# Altium schematic sheet Battery Pack_P1_REV_1.SchDoc
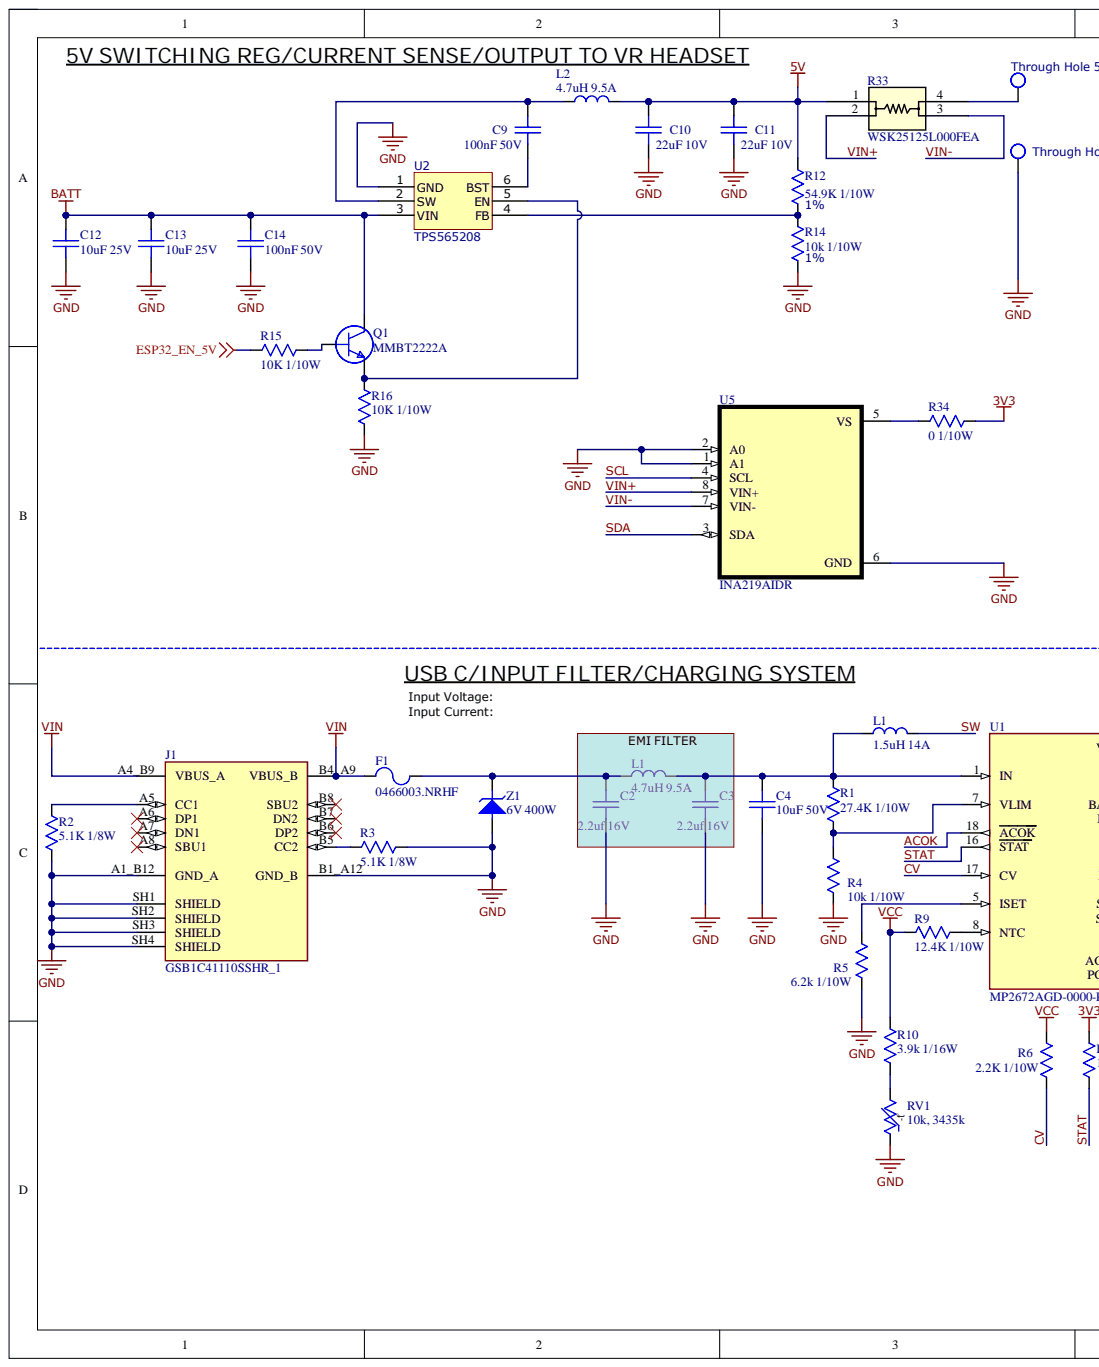
[diagram p0: Battery Pack_P1_REV_1.SchDoc, left]
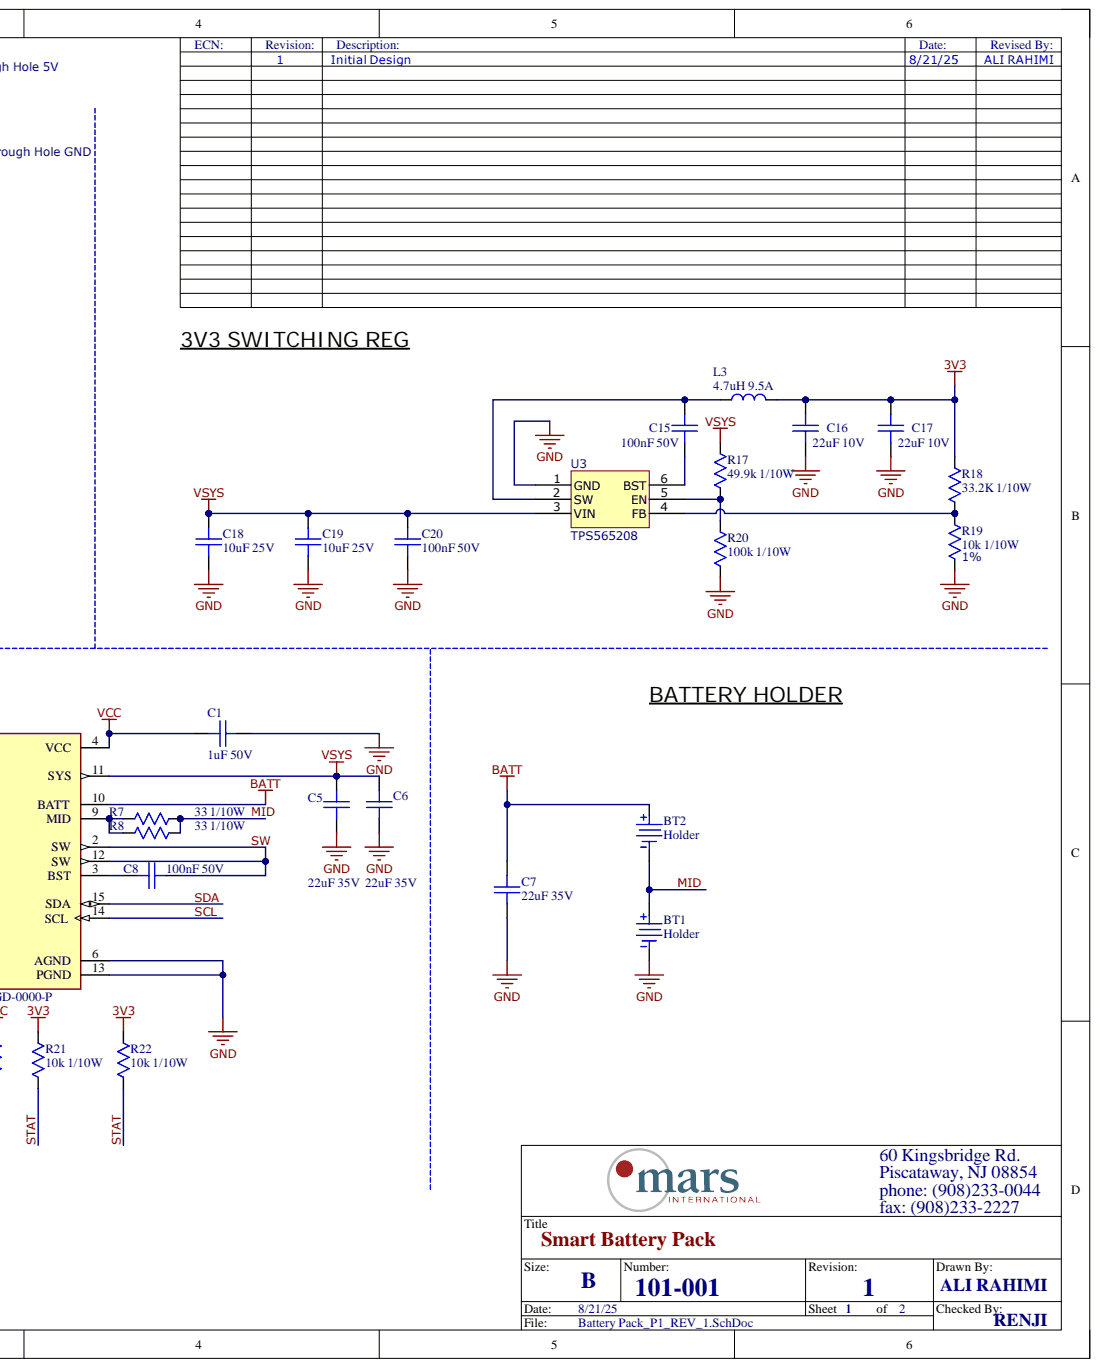
[diagram p1: Battery Pack_P1_REV_1.SchDoc, right]

## Parts
BT1  Holder  [BATTERY_HOLDER_BU2032]
BT2  Holder  [BATTERY_HOLDER_BU2032]
C1  1uF 50V  Voltage Rating: 50V  [IPC-0603]
C2  2.2uf 16V  Voltage Rating: 16V  [IPC-0603]
C3  2.2uf 16V  Voltage Rating: 16V  [IPC-0603]
C4  10uF 50V  [IPC-0805]
C5  22uF 35V  [IPC-0805]
C6  22uF 35V  [IPC-0805]
C7  22uF 35V  Voltage Rating: 35V  [IPC-0805]
C8  100nF 50V  Voltage Rating: 50V  [IPC-0603]
C9  100nF 50V  Voltage Rating: 50V  [IPC-0603]
C10  22uF 10V  Voltage Rating: 10V  [IPC-1206]
C11  22uF 10V  Voltage Rating: 10V  [IPC-1206]
C12  10uF 25V  [IPC-1206]
C13  10uF 25V  Voltage Rating: 25V  [IPC-1206]
C14  100nF 50V  [IPC-0603]
C15  100nF 50V  Voltage Rating: 50V  [IPC-0603]
C16  22uF 10V  Voltage Rating: 10V  [IPC-1206]
C17  22uF 10V  Voltage Rating: 10V  [IPC-1206]
C18  10uF 25V  Voltage Rating: 25V  [IPC-1206]
C19  10uF 25V  Voltage Rating: 25V  [IPC-1206]
C20  100nF 50V  [IPC-0603]
F1  0466003.NRHF  [FUSC3115X66N]
J1  GSB1C41110SSHR_1  [AMPHENOL_GSB1C41110SSHR]
L1  1.5uH 14A  [IND_SRP5030CA_BRN]
L1  4.7uH 9.5A  RMS Current (Irms): 9.5A  [IND_11X10MM]
L2  4.7uH 9.5A  RMS Current (Irms): 9.5A  [IND_11X10MM]
L3  4.7uH 9.5A  RMS Current (Irms): 9.5A  [IND_11X10MM]
Q1  MMBT2222A  [SOT-23_BEC]
R1  27.4K 1/10W  Voltage Rating: 50V  [IPC-0603]
R2  5.1K 1/8W  [IPC-0805]
R3  5.1K 1/8W  [IPC-0805]
R4  10k 1/10W  [IPC-0603]
R5  6.2k 1/10W  [IPC-0603]
R6  2.2K 1/10W  [IPC-0603]
R7  33 1/10W  [IPC-0603]
R8  33 1/10W  [IPC-0603]
R9  12.4K 1/10W  [IPC-0603]
R10  3.9k 1/16W  [IPC-0402]
R12  54.9K 1/10W  Tolerance: 1%  [IPC-0603]
R14  10k 1/10W  Tolerance: 1%  [IPC-0603]
R15  10K 1/10W  [IPC-0603]
R16  10K 1/10W  [IPC-0603]
R17  49.9k 1/10W  [IPC-0603]
R18  33.2K 1/10W  [IPC-0603]
R19  10k 1/10W  Tolerance: 1%  [IPC-0603]
R20  100k 1/10W  [IPC-0603]
R21  10k 1/10W  [IPC-0603]
R22  10k 1/10W  [IPC-0603]
R33  WSK25125L000FEA  [WSK2512_0.005-0.2_VIS]
R34  0 1/10W  [IPC-0603]
RV1  10k, 3435k  [NA]
Through_Hole_5V  TP  [TP_TH_40]  (value not drawn)
Through_Hole_GND  TP  [TP_TH_40]  (value not drawn)
U1  MP2672AGD-0000-P  [IC_MP2672AGD-0000-P]
U2  TPS565208  [SOT23-6]
U3  TPS565208  [SOT23-6]
U5  INA219AIDR  [SOIC127P599X175-8N]
Z1  6V 400W  [SMA_DIODE]

## Nets
3V3: C16.2 C17.2 L3.2 R18.2 R21.2 R22.2 R34.2
5V: C10.2 C11.2 L2.2 R12.2 R33.1
BATT: BT2.2 C7.2 C12.2 C13.2 C14.2 Q1.C U1.10(BATT) U2.3(VIN)
ESP32_EN_5V: R15.2
GND: BT1.1 C1.2 C2.1 C3.1 C4.1 C5.1 C6.1 C7.1 C10.1 C11.1 C12.1 C13.1 C14.1 C16.1 C17.1 C18.1 C19.1 C20.1 J1.A1_B12(GND_A) J1.B1_A12(GND_B) J1.SH1(SHIELD) J1.SH2(SHIELD) J1.SH3(SHIELD) J1.SH4(SHIELD) R2.1 R3.1 R4.1 R5.1 R14.1 R16.1 R19.1 R20.2 RV1.1 Through_Hole_GND.1 U1.6(AGND) U1.13(PGND) U2.1(GND) U3.1(GND) U5.1(A1) U5.2(A0) U5.6(GND) Z1.A
VCC: C1.1 R6.2 R9.1 R10.2 U1.4(VCC)
VIN: F1.1 J1.A4_B9(VBUS_A) J1.B4_A9(VBUS_B)
VSYS: C5.2 C6.2 C18.2 C19.2 C20.2 R17.2 U1.11(SYS) U3.3(VIN)
ACOK: U1.18(ACOK)
CV: R6.1 U1.17(CV)
MID: BT1.2 BT2.1 R7.2 R8.2
SCL: U1.14(SCL) U5.4(SCL)
SDA: U1.15(SDA) U5.3(SDA)
STAT: R21.1 R22.1 U1.16(STAT)
SW: C8.2 L1.2 U1.2(SW) U1.12(SW)
VIN+: R33.2 U5.8(VIN+)
VIN-: R33.3 U5.7(VIN-)
NetC2_2: C2.2 F1.2 L1.1 Z1.K
NetC3_2: C3.2 C4.2 L1.1 L1.2 R1.2 U1.1(IN)
NetC8_1: C8.1 U1.3(BST)
NetC9_1: C9.1 U2.6(BST)
NetC9_2: C9.2 L2.1 U2.2(SW)
NetC15_1: C15.1 U3.6(BST)
NetC15_2: C15.2 L3.1 U3.2(SW)
NetJ1_A5: J1.A5(CC1) R2.2
NetJ1_B5: J1.B5(CC2) R3.2
NetQ1_B: Q1.B R15.1
NetQ1_E: Q1.E R16.2 U2.5(EN)
NetR1_1: R1.1 R4.2 U1.7(VLIM)
NetR5_2: R5.2 U1.5(ISET)
NetR7_1: R7.1 R8.1 U1.9(MID)
NetR9_2: R9.2 U1.8(NTC)
NetR10_1: R10.1 RV1.2
NetR12_1: R12.1 R14.2 U2.4(FB)
NetR17_1: R17.1 R20.1 U3.5(EN)
NetR18_1: R18.1 R19.2 U3.4(FB)
NetR33_4: R33.4 Through_Hole_5V.1
NetR34_1: R34.1 U5.5(VS)

Not connected: J1 A6(DP1) A7(DN1) A8(SBU1) B6(DP2) B7(DN2) B8(SBU2)

## Library data of the parts
BT1 BT2 = O-0652-01: BATTERY HOLDER 2032 SMD; AVL Part Numbers: BU2032SM-BT-GTR; Manufacturer Part Number: BU2032SM-JJ-GTR; Approved: Yes; AVL Vendors: MPD; Connector Type: Snap-In; Current: NA; Database Table Name: Battery Terminal; Plating: 0.020" thick PHOS-BRONZE, GOLD FLASH; Case/Package: SMT; Component Kind: Standard; Height: 0.21"; Length: 1.12"; Manufacturer: MPD; Material: 30% glass reinforced Liquid           Crystal Polymer resin; Max Operating Temperature: 280°C; Min Operating Temperature: -40°C; PT Number: O-0652-01; Supplier 1: Digikey; Supplier Part Number 1: BU2032SM-ND; Voltage: NA; Width: 0.79"; Approved By: ADMIN; Created By: ADMIN; Library Name: MarsLibrary_Test_Environment.DbLib
C1 = SC-0786-01: CAP CER 1UF 50V X5R 0603; Manufacturer: Taiyo Yuden; Manufacturer Part Number: UMK107BJ105KA-T; Approved: Yes; Database Table Name: Capacitors - Surface Mount; Dielectric Material: Ceramic; ESR: NA; Tolerance: 10%; Case/Package: 0603; Component Kind: Standard; Dielectric: X5R; Height: 0.035"; Length: 0.063"; Life(Hours): NA; Max Operating Temperature: 85°C; Min Operating Temperature: -55°C; PT Number: SC-0786-01; Ripple Current: NA; Supplier 1: Digikey; Supplier Part Number 1: 587-2400-2-ND; Width: 0.031"; Approved By: ADMIN; Created By: ADMIN; Library Name: MarsLibrary_Test_Environment.DbLib
C2 C3 = SC-0561-01: CAP CER 2.2UF 16V X5R 0603; Manufacturer: Kemet; Manufacturer Part Number: C0603C225K4PACTU; Approved: Yes; Database Table Name: Capacitors - Surface Mount; Dielectric Material: Ceramic; ESR: NA; Tolerance: 10%; Case/Package: 0603; Component Kind: Standard; Dielectric: X5R; Height: 0.034"; Length: 0.063"; Life(Hours): NA; Max Operating Temperature: 85°C; Min Operating Temperature: -55°C; PT Number: SC-0561-01; Ripple Current: NA; Supplier 1: Digikey; Supplier Part Number 1: 399-7886-1-ND; Width: 0.031"; Approved By: ADMIN; Created By: ADMIN; Library Name: MarsLibrary_Test_Environment.DbLib
C4 = SC-0956-01: CAP CER 10UF 50V X5R 0805; Manufacturer: Murata; Manufacturer Part Number: GRM21BR61H106ME43L; Approved: Yes; Database Table Name: Capacitors - Surface Mount; Dielectric Material: Ceramic; ESR: NA; Tolerance: 20%; Case/Package: 0805; Component Kind: Standard; Dielectric: X5R; Height: 0.057"; Length: 0.079"; Life(Hours): NA; Max Operating Temperature: 85°C; Min Operating Temperature: -55°C; PT Number: SC-0956-01; Ripple Current: NA; Supplier 1: Digikey; Supplier Part Number 1: 490-18664-2-ND; Voltage Rating: 50V; Width: 0.049"; Approved By: BKW; Created By: AW; Library Name: MarsLibrary_Test_Environment.DbLib
C5-C7 = SC-0905-01: CAP CER 22UF 35V X5R 0805; Manufacturer: TDK; Manufacturer Part Number: C2012X5R1V226M125AC; Approved: No; Database Table Name: Capacitors - Surface Mount; Dielectric Material: Ceramic; ESR: NA; Tolerance: 20%; Case/Package: 0805; Component Kind: Standard; Dielectric: X5R; Height: 0.057"; Length: 0.079"; Life(Hours): NA; Max Operating Temperature: 85°C; Min Operating Temperature: -55°C; PT Number: SC-0905-01; Ripple Current: NA; Supplier 1: Digikey; Supplier Part Number 1: 445-14428-2-ND; Width: 0.049"; Created By: BKW; Library Name: MarsLibrary_Test_Environment.DbLib
  C5 C6: Voltage Rating: 35V
C8 = SC-0201-01: CAP CER 0.1uF 50V X7R 0603; Manufacturer: AVX; Manufacturer Part Number: 06035C104KAT2A; Approved: Yes; Database Table Name: Capacitors - Surface Mount; Dielectric Material: Ceramic; ESR: NA; Tolerance: 10%; Case/Package: 0603; Component Kind: Standard; Dielectric: X7R; Height: 0.035"; Length: 0.063"; Life(Hours): NA; Max Operating Temperature: 125°C; Min Operating Temperature: -55°C; PT Number: SC-0201-01; Ripple Current: NA; Supplier 1: Mouser; Supplier Part Number 1: 581-06035C104KAT2A; Width: 0.032"; Approved By: ADMIN; Created By: ADMIN; Library Name: MarsLibrary_Test_Environment.DbLib
C9 C14 C15 C20 = SC-0201-01: CAP CER 0.1uF 50V X7R 0603; AVL Part Numbers: GRM188R71H104KA93D, CL10B104KB8NNNC, 0603B104K500BD, CC0603KRX7R9BB104, C0603T104K5RALTU, C1608X7R104KGTS; Manufacturer: AVX; Manufacturer Part Number: 06035C104KAT2A; Approved: False; AVL Vendors: Murata, Samsung, Team Young, Yageo, Kemet , Darfon; Database Table Name: Capacitors - Surface Mount; Dielectric Material: Ceramic; ESR: NA; Tolerance: 10%; Case/Package: 0603; Component Kind: Standard; Dielectric: X7R; Height: 0.035"; Length: 0.063"; Life(Hours): NA; Max Operating Temperature: 125°C; Min Operating Temperature: -55°C; PT Number: SC-0201-01; Ripple Current: NA; Supplier 1: Mouser; Supplier Part Number 1: 581-06035C104KAT2A; Width: 0.032"; Library Name: MarsLibrary_Test_Environment.DbLib
  C14 C20: Voltage Rating: 50V
C10 C11 C16 C17 = SC-0627-01: Cap Ceramic 22uF 10V X7R 10% SMD 1206 125C Embossed T/R; AVL Part Numbers: CL31B226KPHNNNE; Approved: True; AVL Vendors: Samsung; Database Table Name: Capacitors - Surface Mount; ESR: NA; Case/Package: 1206; Component Kind: Standard; Dielectric: X7R; Dielectric Material: Ceramic; Height: 0.071"; Length: 0.126"; Life(Hours): NA; Manufacturer: Samsung; Manufacturer Part Number: CL31B226KPHNNNE; Max Operating Temperature: 125°C; Min Operating Temperature: -55°C; PT Number: SC-0627-01; Ripple Current: NA; Supplier 1: Digi-Key; Supplier Part Number 1: 1276-2769-1-ND; Tolerance: 10%; Width: 0.063"; Library Name: MarsLibrary_Test_Environment.DbLib
C12 C13 C18 C19 = SC-0754-01: Multilayer Ceramic Capacitors MLCC - SMD/SMT 10uF 25Volts X7R 20%; AVL Part Numbers: GRM31CR71E106MA12L; Approved: True; AVL Vendors: Murata; Database Table Name: Capacitors - Surface Mount; ESR: NA; Case/Package: 1206; Component Kind: Standard; Dielectric: X7R; Dielectric Material: Ceramic; Height: 0.071"; Length: 0.126"; Life(Hours): NA; Manufacturer: Murata; Manufacturer Part Number: GRM31CR71E106MA12L; Max Operating Temperature: 125°C; Min Operating Temperature: -55°C; PT Number: SC-0754-01; Ripple Current: NA; Supplier 1: Digi-Key; Supplier Part Number 1: 490-6519-1-ND; Tolerance: 20%; Width: 0.063"; Library Name: MarsLibrary_Test_Environment.DbLib
  C12: Voltage Rating: 25V
F1 = 0466003.NRHF: 478 Series 1206 Fast-Acting Fuse; MF: Littelfuse Inc.; Check_prices: https://www.snapeda.com/parts/0466003.NRHF/Littelfuse+Inc./view-part/?ref=eda; Component Kind: Standard; Library Name: Schlib1.SchLib; MP: 0466003.NRHF; Package: 1206 Littelfuse Inc.; SnapEDA_Link: https://www.snapeda.com/parts/0466003.NRHF/Littelfuse+Inc./view-part/?ref=snap
J1 = GSB1C41110SSHR_1: USB2.0, Type C, Top mount, Center Height 1.63mm, Single Row Surface Mount; MF: Amphenol Canada; Check_prices: https://www.snapeda.com/parts/GSB1C41110SSHR/Amphenol/view-part/?ref=eda; Component Kind: Standard; Library Name: Schlib1.SchLib; MP: GSB1C41110SSHR; Package: None; SnapEDA_Link: https://www.snapeda.com/parts/GSB1C41110SSHR/Amphenol/view-part/?ref=snap
L1 = L-0210-01: IND SMD 2.2UH 7A; Manufacturer: Bourns; Manufacturer Part Number: SRP5030CC-2R2M; Approved: Yes; Database Table Name: Inductors; DC Resistance (DCR): 32mOhm; Material: Ferrite; Saturation Current: NA; Shielding: Shielded; Tolerance: 20%; Case/Package: 5.7x5.2mm; Component Kind: Standard; Height: 3mm; Length: 5.7mm; Max Operating Temperature: 125°C; Min Operating Temperature: -40°C; PT Number: L-0210-01; RMS Current (Irms): 7A; RoHS: Yes; Supplier 1: Digikey; Supplier Part Number 1: 118-SRP5030CC-2R2MCT-ND; Width: 5.2mm; Approved By: BKW; Created By: MAR; Library Name: MarsLibrary_Test_Environment.DbLib
L1 = L-0191-01: FIXED IND 4.7UH 9.5A 16.5MOHM SMD SHIELDED; Manufacturer: Laird; Manufacturer Part Number: MGV10044R7M-10; Approved: Yes; Database Table Name: Inductors; Material: Ferrite; Saturation Current: 17A; Tolerance: 20%; Case/Package: 11x10mm; Component Kind: Standard; DC Resistance (DCR): 16.5 mOhms; Height: 0.17"; Length: 0.452"; Max Operating Temperature: 125°C; Min Operating Temperature: -40°C; PT Number: L-0191-01; RoHS: Yes; Shielding: Shielded; Supplier 1: Digikey; Supplier Part Number 1: 240-2819-1-ND; Width: 0.405"; Approved By: ADMIN; Created By: ADMIN; Library Name: MarsLibrary_Test_Environment.DbLib
L2 L3 = L-0191-01: FIXED IND 4.7UH 9.5A 16.5MOHM SMD SHIELDED; AVL Part Numbers: MGV10044R7M-10; Approved: False; AVL Vendors: Laird; Database Table Name: Inductors; Material: Ferrite; Saturation Current: 17A; Tolerance: 20%; Case/Package: 11x10mm; Component Kind: Standard; DC Resistance (DCR): 16.5 mOhms; Height: 0.17"; Length: 0.452"; Manufacturer: Laird; Manufacturer Part Number: MGV10044R7M-10; Max Operating Temperature: 125°C; Min Operating Temperature: -40°C; PT Number: L-0191-01; Shielding: Shielded; Supplier 1: Digikey; Supplier Part Number 1: 240-2819-1-ND; Width: 0.405"; Library Name: MarsLibrary_Test_Environment.DbLib
Q1 = T-0027-01: TRANS NPN 40V 0.6A SOT-23; Manufacturer: Diodes; Manufacturer Part Number: MMBT2222A-7-F; Approved: Yes; Collector Base Voltage (VCBO): 75V; Collector Emitter Saturation Voltage: 300mV; Current Rating: 600mA; Database Table Name: Transistors - Bipolar; Emitter Base Voltage (VEBO): 6V; hFE/Beta Min: 100; Power Dissipation: 300mW; Case/Package: SOT-23-3; Collector Emitter Voltage (VCEO): 40V; Component Kind: Standard; Height: 1.1mm; Length: 3.0mm; Max Collector Current: 600mA; Max Operating Temperature: 150°C; Min Operating Temperature: -55°C; PT Number: T-0027-01; RoHS: Yes; Supplier 1: Digikey; Supplier Part Number 1: MMBT2222A-FDICT-ND; Width: 2.5mm; Approved By: ADMIN; Created By: ADMIN; Library Name: MarsLibrary_Test_Environment.DbLib
R1 = R-1015-02: RES SMD 27.4K 1% 1/10W 0603; Manufacturer: Yageo; Manufacturer Part Number: RC0603FR-0727K4L; Approved: Yes; Array: NA; Database Table Name: Resistors; Power Rating: 100mW; Temperature Coefficient: ±100ppm/°C; Case/Package: 0603; Component Kind: Standard; Composition: Thick Film; Height: 0.022"; Length: 0.063"; Max Operating Temperature: 155°C; Min Operating Temperature: -55°C; PT Number: R-1015-02; RoHS: Yes; Supplier 1: Digikey; Supplier Part Number 1: 311-27.4KHRTR-ND; Tolerance: 1%; Width: 0.031"; Approved By: BKW; Created By: ADMIN; Library Name: MarsLibrary_Test_Environment.DbLib
R2 R3 = R-1751-01: RES SMD 5.1K OHM 5% 1/8W 0805; Manufacturer: Panasonic; Manufacturer Part Number: ERJ-6GEYJ512V; Approved: No; Array: NA; Database Table Name: Resistors; Power Rating: 125mW; Temperature Coefficient: ±200ppm/°C; Voltage Rating: 150V; Case/Package: 0805; Component Kind: Standard; Composition: Thick Film; Height: 0.020"; Length: .079"; Max Operating Temperature: 155°C; Min Operating Temperature: -55°C; PT Number: R-1751-01; RoHS: Yes; Supplier 1: Digikey; Supplier Part Number 1: P5.1KATR-ND; Tolerance: 5%; Width: 0.049"; Created By: BKW; Library Name: MarsLibrary_Test_Environment.DbLib
R4 = R-0652-01: RES SMD 10K OHM 1% 1/10W 0603; Manufacturer: Yageo; Manufacturer Part Number: RC0603FR-0710KL; Approved: Yes; Array: NA; Database Table Name: Resistors; Power Rating: 100mW; Temperature Coefficient: ±100ppm/°; Voltage Rating: 75V; Case/Package: 0603; Component Kind: Standard; Composition: Thick Film; Height: 0.022"; Length: 0.063"; Max Operating Temperature: 155°C; Min Operating Temperature: -55°C; PT Number: R-0652-01; RoHS: Yes; Supplier 1: Digikey; Supplier Part Number 1: 311-10.0KHRTR-ND; Tolerance: 1%; Width: 0.031"; Approved By: ADMIN; Created By: ADMIN; Library Name: MarsLibrary_Test_Environment.DbLib
R5 = R-2059-01: RES 6.2K OHM 1% 1/10W 0603; Manufacturer: Yageo; Manufacturer Part Number: RC0603FR-076K2L; Approved: No; Array: NA; Database Table Name: Resistors; Power Rating: 100mW; Temperature Coefficient: ±100ppm/°C; Voltage Rating: 75V; Case/Package: 0603; Component Kind: Standard; Composition: Thick Film; Height: 0.022"; Length: 0.063"; Max Operating Temperature: 155°C; Min Operating Temperature: -55°C; PT Number: R-2059-01; RoHS: Yes; Supplier 1: Digikey; Supplier Part Number 1: 311-6.20KHRTR-ND; Tolerance: 1%; Width: 0.031"; Created By: BKW; Library Name: MarsLibrary_Test_Environment.DbLib
R6 = R-0073-01: RES SMD 2.2K OHM 5% 1/10W 0603; Manufacturer: Yageo; Manufacturer Part Number: RC0603JR-072K2; Approved: Yes; Array: NA; Database Table Name: Resistors; Power Rating: 100mW; Temperature Coefficient: ±100ppm/°C; Voltage Rating: 75V; Case/Package: 0603; Component Kind: Standard; Composition: Thick Film; Height: 0.022"; Length: 0.063"; Max Operating Temperature: 155°C; Min Operating Temperature: -55°C; PT Number: R-0073-01; RoHS: Yes; Supplier 1: Digikey; Supplier Part Number 1: 311-2.2KGRTR-ND; Tolerance: 5%; Width: 0.031"; Approved By: ADMIN; Created By: ADMIN; Library Name: MarsLibrary_Test_Environment.DbLib
R7 R8 = R-0124-01: RES SMD 33 OHM 5% 1/10W 0603; Manufacturer: Panasonic; Manufacturer Part Number: ERJ-3GEYJ330V  ERJ-3GEYJ330V; Approved: Yes; Array: NA; Database Table Name: Resistors; Power Rating: 100mW; Temperature Coefficient: ±200ppm/°C; Voltage Rating: 75V; Case/Package: 0603; Component Kind: Standard; Composition: Thick Film; Height: 0.022"; Length: 0.063"; Max Operating Temperature: 155°C; Min Operating Temperature: -55°C; PT Number: R-0124-01; RoHS: Yes; Supplier 1: Digikey; Supplier Part Number 1: P33GTR-ND; Tolerance: 5%; Width: 0.031"; Approved By: BKW; Created By: ADMIN; Library Name: MarsLibrary_Test_Environment.DbLib
R9 = R-0952-01: RES 12.4K OHM 1% 1/10W 0603; Manufacturer: Vishay; Manufacturer Part Number: CRCW060312K4FKEA; Approved: Yes; Array: NA; Database Table Name: Resistors; Power Rating: 100mW; Temperature Coefficient: ±100ppm/°C; Voltage Rating: 75V; Case/Package: 0603; Component Kind: Standard; Composition: Thick Film; Height: 0.020"; Length: 0.063"; Max Operating Temperature: 155°C; Min Operating Temperature: -55°C; PT Number: R-0952-01; RoHS: Yes; Supplier 1: Digikey; Supplier Part Number 1: 541-12.4KHTR-ND; Tolerance: 1%; Width: 0.031"; Approved By: BKW; Created By: DJS; Library Name: MarsLibrary_Test_Environment.DbLib
R10 = R-1543-01: RES SMD 3.9K OHM 5% 1/16W 0402; Manufacturer: Yageo; Manufacturer Part Number: RC0402JR-073K9L; Approved: Yes; Array: NA; Database Table Name: Resistors; Power Rating: 62.5mW; Temperature Coefficient: ±100ppm/°C; Voltage Rating: 50V; Case/Package: 0402; Component Kind: Standard; Composition: Thick Film; Height: 0.016"; Length: 0.039"; Max Operating Temperature: 155°C; Min Operating Temperature: -55°C; PT Number: R-1543-01; RoHS: Yes; Supplier 1: Digikey; Supplier Part Number 1: 311-3.9KJRTR-ND; Tolerance: 5%; Width: 0.020"; Approved By: ADMIN; Created By: ADMIN; Library Name: MarsLibrary_Test_Environment.DbLib
R12 = R-1822-01: RES SMD 54.9K OHM 1% 1/10W 0603; AVL Part Numbers: RK73H1JTTD5492F; Approved: True; Array: NA; AVL Vendors: Koa; Database Table Name: Resistors; Power Rating: 100mW; Temperature Coefficient: ±100ppm/°C; Voltage Rating: 75V; Case/Package: 0603; Component Kind: Standard; Composition: Thick FIlm; Height: 0.018"; Length: 0.063"; Manufacturer: Koa; Manufacturer Part Number: RK73H1JTTD5492F; Max Operating Temperature: 155°C; Min Operating Temperature: -55°C; PT Number: R-1822-01; RoHS: False; Supplier 1: Digikey; Supplier Part Number 1: 2019-RK73H1JTTD5492FCT-ND; Width: 0.031"; Library Name: MarsLibrary_Test_Environment.DbLib
R14 R19 = R-0652-01: RES SMD 10K OHM 1% 1/10W 0603; AVL Part Numbers: NRC06F1002TRF, RC0603FR-0710KL, MCR03EZPX1002, RCT03103FLF, RCT03FT103, RCT0310KFLF; Manufacturer: Yageo; Manufacturer Part Number: RC0603FR-0710KL; Approved: True; Array: NA; AVL Vendors: NIC, Yageo, Rohm, HK Resisor, HK Resisor, HK Resistor; Database Table Name: Resistors; Power Rating: 100mW; Temperature Coefficient: ±100ppm/°; Voltage Rating: 75V; Case/Package: 0603; Component Kind: Standard; Composition: Thick Film; Height: 0.022"; Length: 0.063"; Max Operating Temperature: 155°C; Min Operating Temperature: -55°C; PT Number: R-0652-01; RoHS: True; Supplier 1: Digikey; Supplier Part Number 1: 311-10.0KHRTR-ND; Width: 0.031"; Library Name: MarsLibrary_Test_Environment.DbLib
R15 R16 = R-0592-01: RES 10K OHM 5% 1/10W 0603; Manufacturer: Yageo; Manufacturer Part Number: RC0603JR-0710KL; Approved: Yes; Array: NA; Database Table Name: Resistors; Power Rating: 100mW; Temperature Coefficient: ±100ppm/°C; Voltage Rating: 75V; Case/Package: 0603; Component Kind: Standard; Composition: Thick Film; Height: 0.022"; Length: 0.063"; Max Operating Temperature: 155°C; Min Operating Temperature: -55°C; PT Number: R-0592-01; RoHS: Yes; Supplier 1: Digikey; Supplier Part Number 1: 311-10KGRCT-ND; Tolerance: 5%; Width: 0.031"; Approved By: BKW; Created By: MAR; Library Name: MarsLibrary_Test_Environment.DbLib
R17 = R-1077-02: RES SMD 49.9K OHM 1% 1/10W 0603; Manufacturer: Yageo; Manufacturer Part Number: RC0603FR-0749K9L; Approved: Yes; Array: NA; Database Table Name: Resistors; Power Rating: 100mW; Temperature Coefficient: ±100ppm/°; Voltage Rating: 50V; Case/Package: 0603; Component Kind: Standard; Composition: Thick Film; Height: 0.022"; Length: 0.063"; Max Operating Temperature: 155°C; Min Operating Temperature: -55°C; PT Number: R-1077-02; RoHS: Yes; Supplier 1: Digikey; Supplier Part Number 1: 311-49.9KHRCT-ND; Tolerance: 1%; Width: 0.031"; Approved By: ADMIN; Created By: ADMIN; Library Name: MarsLibrary_Test_Environment.DbLib
R18 = R-1507-01: RES SMD 33.2K OHM 1% 1/10W 0603; Manufacturer: Yageo; Manufacturer Part Number: RC0603FR-0733K2L; Approved: Yes; Array: NA; Database Table Name: Resistors; Power Rating: 100mW; Temperature Coefficient: ±100ppm/°C; Voltage Rating: 75V; Case/Package: 0603; Component Kind: Standard; Composition: Thick FIlm; Height: 0.022"; Length: 0.063"; Max Operating Temperature: 155°C; Min Operating Temperature: -55°C; PT Number: R-1507-01; RoHS: Yes; Supplier 1: Digikey; Supplier Part Number 1: 311-33.2KHRTR-ND; Tolerance: 1%; Width: 0.031"; Approved By: ADMIN; Created By: ADMIN; Library Name: MarsLibrary_Test_Environment.DbLib
R20 = R-0653-01: RES SMD 100K OHM 1% 1/10W 0603; AVL Part Numbers: NRC06F1003TRF; Manufacturer: Vishay Dale; Manufacturer Part Number: CRCW0603100KFKEA; Approved: True; Array: NA; AVL Vendors: NIC; Database Table Name: Resistors; Power Rating: 100mW; Temperature Coefficient: ±100ppm/°; Voltage Rating: 75V; Case/Package: 0603; Component Kind: Standard; Composition: Thick Film; Height: 0.022"; Length: 0.063"; Max Operating Temperature: 155°C; Min Operating Temperature: -55°C; PT Number: R-0653-01; RoHS: True; Supplier 1: Digikey; Supplier Part Number 1: 541-100KHCT-ND; Tolerance: 1%; Width: 0.031"; Library Name: MarsLibrary_Test_Environment.DbLib
R21 R22 = R-0104-01: RES SMD 10K OHM 5% 1/10W 0603; Manufacturer: Yageo; Manufacturer Part Number: RC0603JR-0710KL; Approved: Yes; Array: NA; Database Table Name: Resistors; Power Rating: 100mW; Temperature Coefficient: ±100ppm/°; Voltage Rating: 75V; Case/Package: 0603; Component Kind: Standard; Composition: Thick Film; Height: 0.031"; Length: 0.063"; Max Operating Temperature: 155°C; Min Operating Temperature: -55°C; PT Number: R-0104-01; RoHS: Yes; Supplier 1: Digikey; Supplier Part Number 1: 311-10KGRCT-ND; Tolerance: 5%; Width: 0.031"; Approved By: ADMIN; Created By: ADMIN; Library Name: MarsLibrary_Test_Environment.DbLib
R33 = WSK25125L000FEA: WSK-2512 .005 1% EA e3; Manufacturer_Name: Vishay; Component Kind: Standard; Datasheet: https://www.vishay.com/doc?30108; Library Name: Schlib1.SchLib; Manufacturer_Part_Number: WSK25125L000FEA; RefDes: RefDes; Type: Type; Copyright: Copyright (C) 2025 Ultra Librarian. All rights reserved.
R34 = R-0103-01: RES SMD 0 OHM 5% 1/10W 0603; Manufacturer: Panasonic; Manufacturer Part Number: ERJ-3GEY0R00V; Approved: Yes; Array: NA; Database Table Name: Resistors; Power Rating: 100mW; Temperature Coefficient: ±100ppm/°C; Voltage Rating: 75V; Case/Package: 0603; Component Kind: Standard; Composition: Thick Film; Height: 0.018"; Length: 0.063"; Max Operating Temperature: 155°C; Min Operating Temperature: -55°C; PT Number: R-0103-01; RoHS: Yes; Supplier 1: Digikey; Supplier Part Number 1: P0.0GCT-ND; Tolerance: 5%; Width: 0.031"; Approved By: ADMIN; Created By: ADMIN; Library Name: MarsLibrary_Test_Environment.DbLib
RV1 = R-2070-01: NTC THERMISTOR 10KOHM 1%; Manufacturer: Semitec USA Corp; Manufacturer Part Number: 103AT-11; Approved: No; Beta Value: 3435; Calibration temperature: 25°C; Database Table Name: RTDs (PTC,NTC,Thermistors); Power: 13mW; Voltage: 1000VAC; Case/Package: Free-Hanging Bead; Component Kind: Standard; Height: 6mm; Hold Current: NA; Length: 600mm; Max Operating Temperature: 105°C; Min Operating Temperature: -50°C; PT Number: R-2070-01; Tolerance: 1%; Trip Current: NA; Width: 5mm; Created By: BKW; Library Name: MarsLibrary_Test_Environment.DbLib
Through_Hole_5V Through_Hole_GND = TestPoint_TH_40mil: Test point, 40mil, TH; AVL Part Numbers: NA; Part Field 1: CN-0160-01; Approved: Yes; Database Table Name: BOM and Mechanical; AVL Vendors: NA; Case/Package: NA; Component Kind: Standard; Height: NA; Length: NA; Manufacturer: NA; Manufacturer Part Number: NA; Max Operating Temperature: NA; Min Operating Temperature: NA; PT Number: TestPoint_TH_40mil; Supplier 1: NA; Supplier Part Number 1: NA; Width: NA; Approved By: ADMIN; Created By: ADMIN; Library Name: MarsLibrary_Test_Environment.DbLib
U1 = MP2672AGD-0000-P: Charger IC Lithium Ion 18-QFN (2x3); MF: Monolithic Power Systems Inc.; Check_prices: https://www.snapeda.com/parts/MP2672AGD-0000-P/Monolithic+Power+Systems/view-part/?ref=eda; Component Kind: Standard; Library Name: Schlib1.SchLib; MP: MP2672AGD-0000-P; Package: QFN-18 MPS; SnapEDA_Link: https://www.snapeda.com/parts/MP2672AGD-0000-P/Monolithic+Power+Systems/view-part/?ref=snap
U2 U3 = U-0836-01: IC REG SWITCHING ADJ 5A TSOT23-6; AVL Part Numbers: TPS565208DDCT; Manufacturer: Texas Instruments; Approved: False; AVL Vendors: TI; Database Table Name: Power Supplies and Voltage Regulators; FCC: NA; Switching Frequency: 500kHz; Tolerance: 1%; Vin (Min-Max): 4.5-17V; Vout: Adj to 7V; Case/Package: TSOT23-6; Component Kind: Standard; Height: 0.044"; Imax: 5A; Length: 0.12"; Manufacturer Part Number: TPS565208; Max Operating Temperature: 125°C; Min Operating Temperature: -40°C; Power: NA; PT Number: U-0836-01; RoHS: False; Supplier 1: TI store; Supplier Part Number 1: TPS565208DDCT; UL: NA; Width: 0.12"; Library Name: MarsLibrary_Test_Environment.DbLib
U5 = INA219AIDR: 26-V 12-bit I2C output digital power monitor; MF: Texas Instruments; Check_prices: https://www.snapeda.com/parts/INA219AIDR/Texas+Instruments/view-part/?ref=eda; Component Kind: Standard; Library Name: Schlib1.SchLib; MP: INA219AIDR; Package: SOIC-8 Texas Instruments; SnapEDA_Link: https://www.snapeda.com/parts/INA219AIDR/Texas+Instruments/view-part/?ref=snap
Z1 = D-0272-01: TVS DIODE 6V 9.2V DO214AC; Manufacturer: Littelfuse; Manufacturer Part Number: SMAJ5.0A; Approved: Yes; Database Table Name: Diodes - TVSS; Direction: Unidirectional; Itest: 10mA; Max Breakdown Voltage: 7.0V; Max Reverse Leakage: 800uA; Min Breakdown Voltage: 6.4V; Standoff Voltage: 5V; Temp Coefficient: NA; Case/Package: DO-214AC, SMA; Component Kind: Standard; Height: 0.090"; Length: 0.181"; Max Clamping Voltage: 9.2V; Max Operating Temperature: 150°C; Min Operating Temperature: -65°C; PT Number: D-0272-01; Supplier 1: Digikey; Supplier Part Number 1: SMAJ5.0ALFTR-ND; Width: 0.110"; Approved By: ADMIN; Created By: ADMIN; Library Name: MarsLibrary_Test_Environment.DbLib
